# Altium schematic sheet 5_UbloxGps.SchDoc(5_UbloxGps)
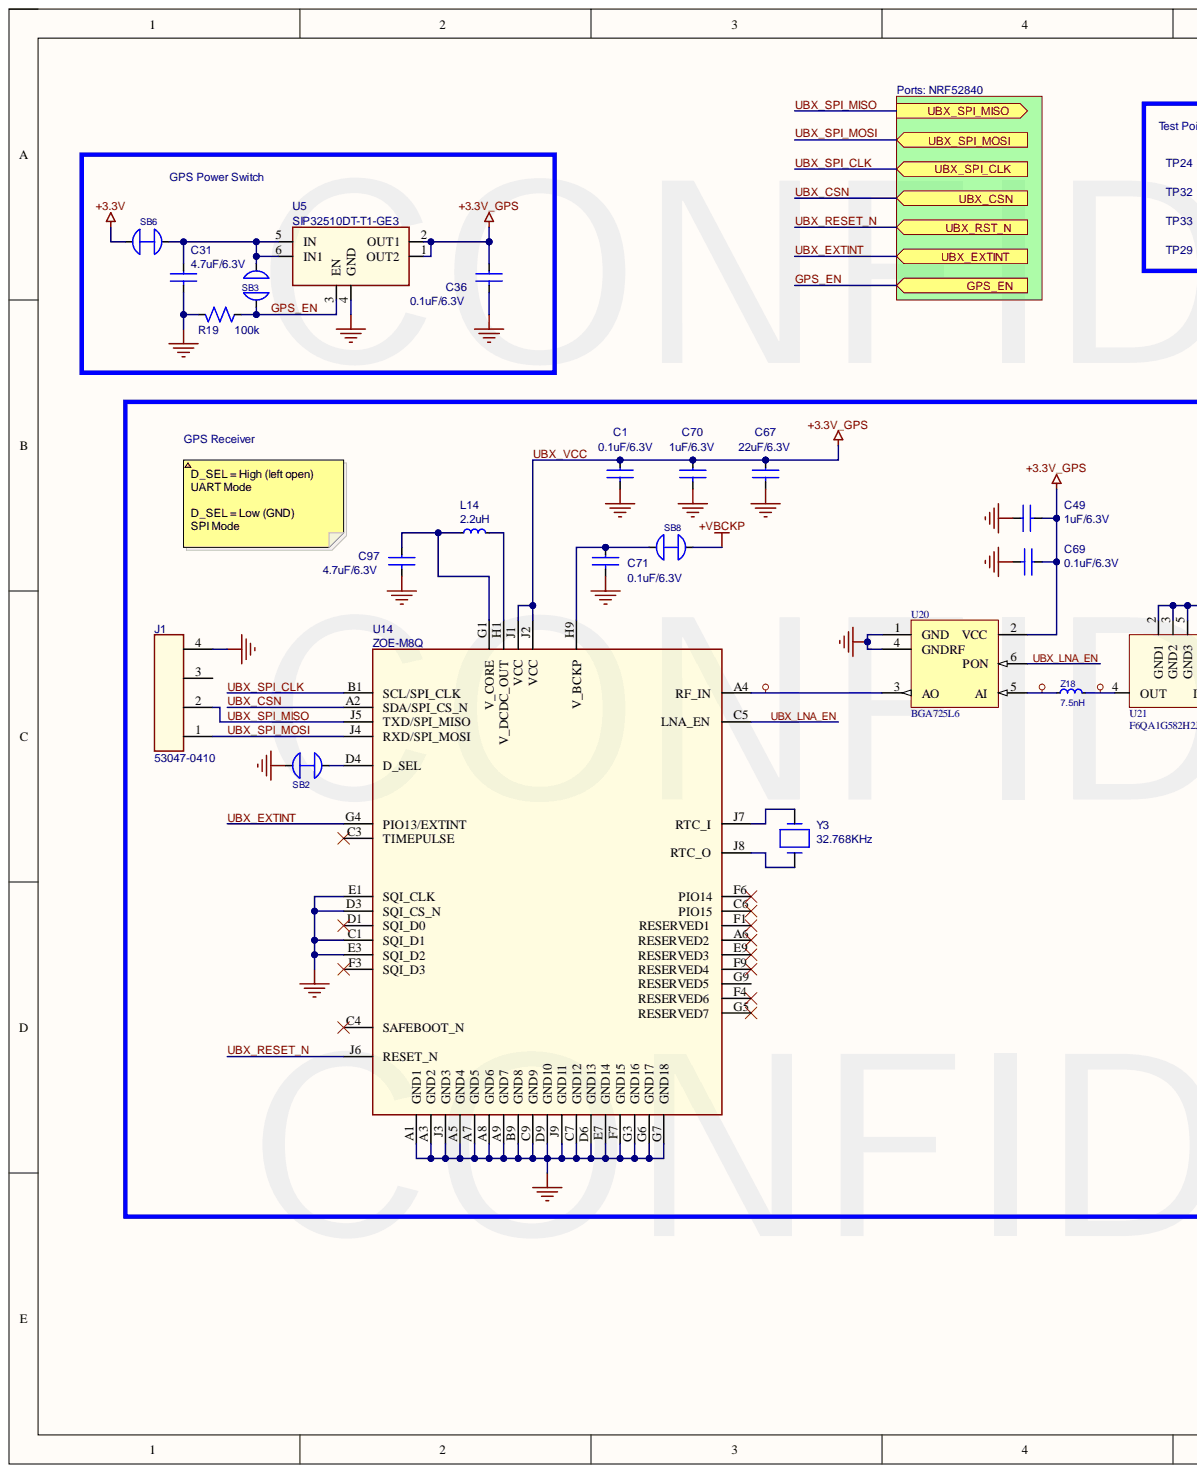
[diagram p0: 5_UbloxGps.SchDoc, left]
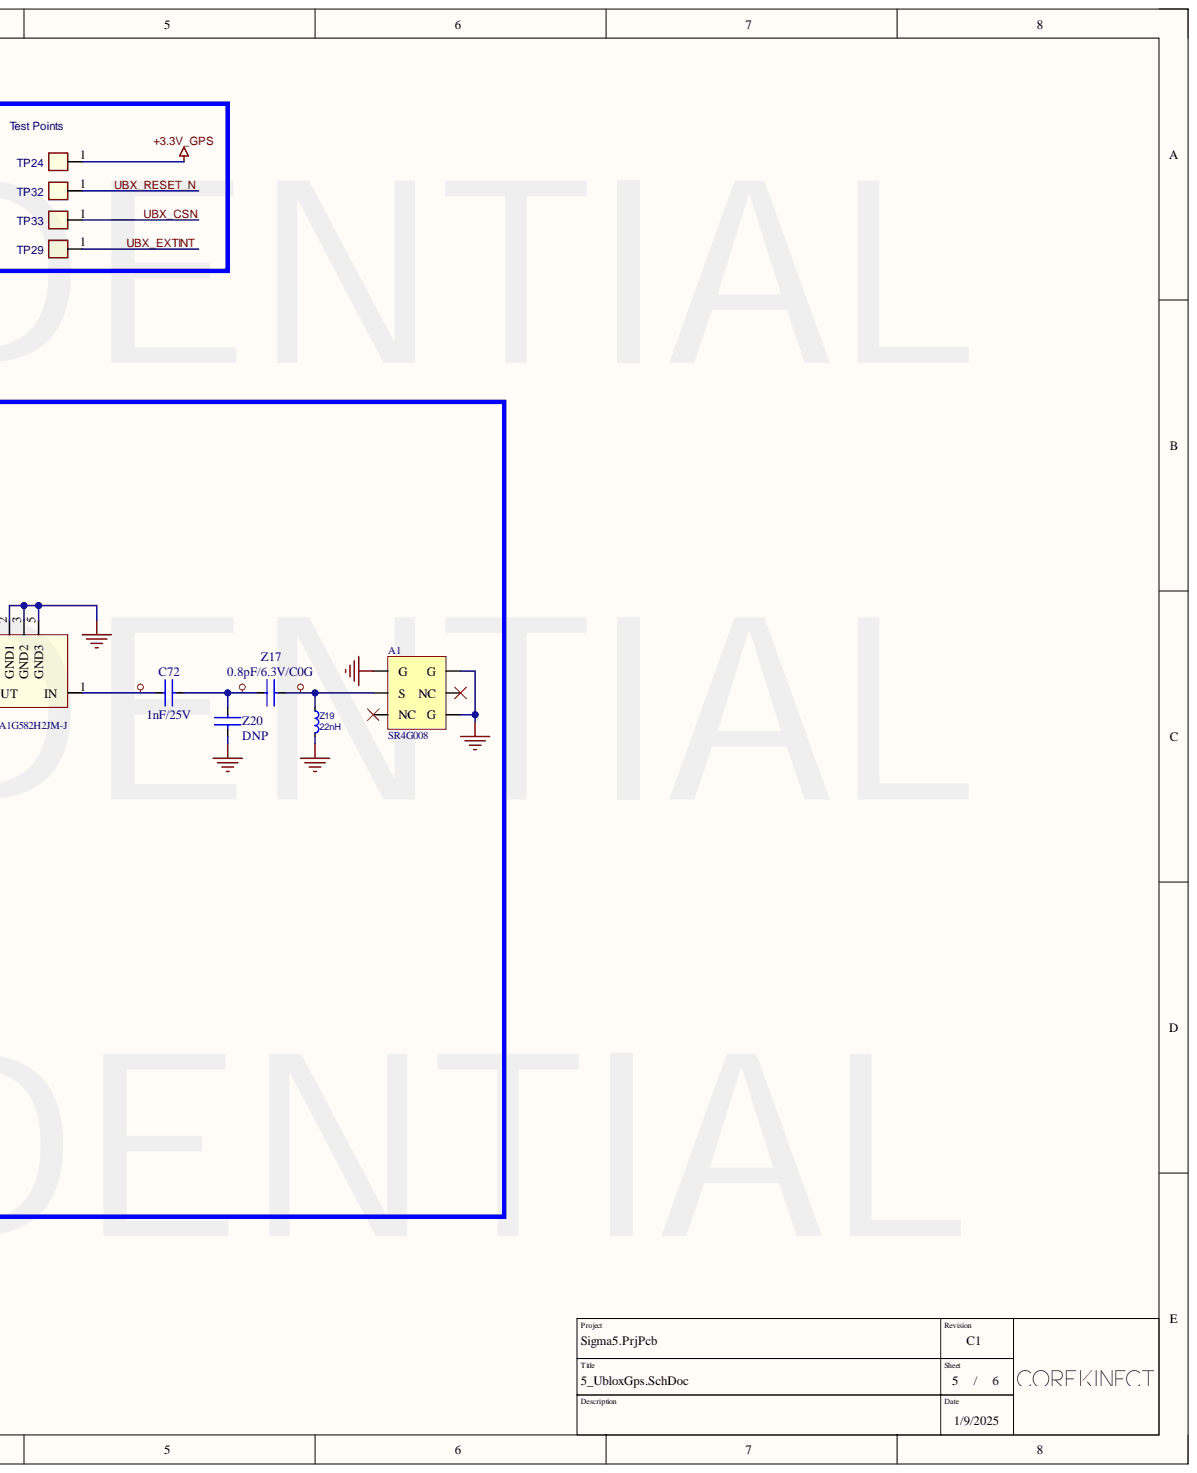
[diagram p1: 5_UbloxGps.SchDoc, right]

## Parts
A1  SR4G008  [SR4G008]
C1  0.1uF/6.3V  [CAP_0402]
C31  4.7uF/6.3V  [CAP_0603]
C36  0.1uF/6.3V  [CAP_0402]
C49  1uF/6.3V  [CAP_0402]
C67  22uF/6.3V  [CAP_0603]
C69  0.1uF/6.3V  [CAP_0402]
C70  1uF/6.3V  [CAP_0402]
C71  0.1uF/6.3V  [CAP_0402]
C72  1nF/25V  [CAP_0402]
C97  4.7uF/6.3V  [CAP_0603]
J1  53047-0410  [0530470410_Molex]
L14  2.2uH  [IND_0806]
R19  100k  [RES_0402]
SB2  SOLDER BRIDGE CLOSED  [SOLDER_BRIDGE_CLOSED_5mil]  (value not drawn)
SB3  SOLDER BRIDGE OPEN  [SOLDER_BRIDGE_OPEN]  (value not drawn)  (no BOM)
SB6  SOLDER BRIDGE CLOSED  [RES_0402]  (value not drawn)
SB8  SOLDER BRIDGE CLOSED  [RES_0402]  (value not drawn)
TP24  TP  [SMT_TP35_SM]
TP29  TP  [SMT_TP35_SM]
TP32  TP  [SMT_TP35_SM]
TP33  TP  [SMT_TP35_SM]
U5  SIP32510DT-T1-GE3  [SOT-23-6]
U14  ZOE-M8Q  [ZOE-M8]
U20  BGA725L6  [INF-TSLP-6-2_V]
U21  F6QA1G582H2JM-J  [F6QA1G582H2JM-J]
Y3  32.768KHz  [XTAL_CM7V-T1A]
Z17  0.8pF/6.3V/C0G  [CAP_0402]
Z18  7.5nH  [CAP_0402]
Z19  22nH  [CAP_0402]
Z20  DNP  [CAP_0402]

## Ports
GPS_EN, UBX_CSN, UBX_EXTINT, UBX_RST_N, UBX_SPI_CLK, UBX_SPI_MISO, UBX_SPI_MOSI

## Nets
+3.3V: SB6.1
+VBCKP: SB8.2
GND: A1.3(G) A1.4(G) A1.6(G) C1.2 C31.2 C36.2 C49.1 C67.2 C69.2 C70.2 C71.2 C97.2 J1.4 R19.2 SB2.1 U5.4(GND) U14.A1(GND1) U14.A3(GND2) U14.A5(GND4) U14.A7(GND5) U14.A8(GND6) U14.A9(GND7) U14.B9(GND8) U14.C1(SQI_D1) U14.C7(GND12) U14.C9(GND9) U14.D3(SQI_CS_N) U14.D6(GND13) U14.D9(GND10) U14.E1(SQI_CLK) U14.E3(SQI_D2) U14.E7(GND14) U14.F7(GND15) U14.G3(GND16) U14.G6(GND17) U14.G7(GND18) U14.J3(GND3) U14.J9(GND11) U20.1(GND) U20.4(GNDRF) U21.2(GND1) U21.3(GND2) U21.5(GND3) Z19.2 Z20.2
GPS_EN: R19.1 SB3.1 U5.3(EN) ; port GPS_EN
UBX_CSN: TP33.1 U14.A2(SDA/SPI_CS_N) ; port UBX_CSN
UBX_EXTINT: TP29.1 U14.G4(PIO13/EXTINT) ; port UBX_EXTINT
UBX_LNA_EN: U14.C5(LNA_EN) U20.6(PON)
UBX_RESET_N: TP32.1 U14.J6(RESET_N) ; port UBX_RST_N
UBX_SPI_CLK: U14.B1(SCL/SPI_CLK) ; port UBX_SPI_CLK
UBX_SPI_MISO: J1.2 U14.J5(TXD/SPI_MISO) ; port UBX_SPI_MISO
UBX_SPI_MOSI: J1.1 U14.J4(RXD/SPI_MOSI) ; port UBX_SPI_MOSI
UBX_VCC: C1.1 C36.1 C49.2 C67.1 C69.1 C70.1 TP24.1 U5.1(OUT2) U5.2(OUT1) U14.J1(VCC) U14.J2(VCC) U20.2(VCC)
NetA1_2: A1.2(S) Z17.2 Z19.1
NetC31_1: C31.1 SB3.2 SB6.2 U5.5(IN) U5.6(IN1)
NetC71_1: C71.1 SB8.1 U14.H9(V_BCKP)
NetC72_1: C72.1 U21.1(IN)
NetC72_2: C72.2 Z17.1 Z20.1
NetC97_1: C97.1 L14.1 U14.G1(V_CORE)
NetL14_2: L14.2 U14.H1(V_DCDC_OUT)
NetSB2_2: SB2.2 U14.D4(D_SEL)
NetU14_A4: U14.A4(RF_IN) U20.3(AO)
NetU14_J7: U14.J7(RTC_I) Y3.2
NetU14_J8: U14.J8(RTC_O) Y3.1
NetU20_5: U20.5(AI) Z18.1
NetU21_4: U21.4(OUT) Z18.2

Not connected: A1 1(NC) 5(NC); J1 3; U14 A6(RESERVED2) C3(TIMEPULSE) C4(SAFEBOOT_N) C6(PIO15) D1(SQI_D0) E9(RESERVED3) F1(RESERVED1) F3(SQI_D3) F4(RESERVED6) F6(PIO14) F9(RESERVED4) G5(RESERVED7) G9(RESERVED5)

## Library data of the parts
A1 = SR4G008: RF ANT 1.561GHZ/1.575GHZ CHIP; Manufacturer 1: Antenova; Notes: GNSS antenna; Number of Bands: 1; Return Loss: -12dB; Category: RF Antennas; Component Kind: Standard; ComponentLink1Description: http://www.antenova-m2m.com/wp-content/uploads/2015/11/Sinica-GNSS-SR4G008-PS-1.1.pdf?src-supplier=Digi-Key; ComponentLink1URL: http://www.antenova-m2m.com/wp-content/uploads/2015/11/Sinica-GNSS-SR4G008-PS-1.1.pdf?src-supplier=Digi-Key; Height: 0.015inch; Manufacturer Part Number 1: SR4G008; Mount: Surface Mount; Packaging: Tape and Reel; Pricing 1: 1=2.79000, 10=2.50400, 25=2.36240, 100=2.01290, 250=1.89000, 500=1.65376 (USD); RoHS: Yes; Stock 1: 11941; Supplier 1: Digi-Key; Supplier Part Number 1: 627-1063-1-ND; Termination: Solder; Library Name: CoreKinectIntegratedLibrary.IntLib
C1 C36 C49 C69-C71 = CAP_0402: Component Kind: Standard; Package / Case: 0402; Library Name: CoreKinectIntegratedLibrary.IntLib
C31 C67 C97 = CAP_0603: Component Kind: Standard; Package / Case: 0603; Library Name: CoreKinectIntegratedLibrary.IntLib
C72 = CAP_0402: Notes: GNSS DC block - High Q; Component Kind: Standard; Package / Case: 0402; Library Name: CoreKinectIntegratedLibrary.IntLib
J1 = MOLEX_53047-0410: GLOVE, POLYAMIDE, L/FREE, PU, SIZE 6 - More Details; Manufacturer 1: Molex; Contact Resistance: 20mR; Current: 1A; Gender: Male; Glow Wire Compliant: Non-compliant; Insulation Resistance: 100MR; Max Voltage Rating (AC): 125V; Number of Circuits: 4; Pitch: 1.25mm; Plating: Tin; Case/Package: 0410; Category: Headers and Wire Housings; Color: Natural; Component Kind: Standard; ComponentLink1Description: https://datasheet.ciiva.com/7844/1838335-7844032.pdf?src-supplier=Element14; ComponentLink1URL: https://datasheet.ciiva.com/7844/1838335-7844032.pdf?src-supplier=Element14; ComponentLink2Description: https://datasheet.ciiva.com/30144/347020530470410-pcb-headers-30144214.pdf?src-supplier=Onlinecomponents.com; ComponentLink2URL: https://datasheet.ciiva.com/30144/347020530470410-pcb-headers-30144214.pdf?src-supplier=Onlinecomponents.com; ComponentLink3Description: https://datasheet.ciiva.com/20207/22-3930e-20207527.pdf?src-supplier=Rapid+Electronics; ComponentLink3URL: https://datasheet.ciiva.com/20207/22-3930e-20207527.pdf?src-supplier=Rapid+Electronics; ComponentLink4Description: https://datasheet.ciiva.com/5149/0530470410-pcb-headers-170953-5149004.pdf?src-supplier=Mouser; ComponentLink4URL: https://datasheet.ciiva.com/5149/0530470410-pcb-headers-170953-5149004.pdf?src-supplier=Mouser; ComponentLink5Description: https://datasheet.ciiva.com/11746/0900766b8024e2fd-11746351.pdf?src-supplier=RSComponents; ComponentLink5URL: https://datasheet.ciiva.com/11746/0900766b8024e2fd-11746351.pdf?src-supplier=RSComponents; ComponentLink6Description: https://datasheet.ciiva.com/16658/0530470410-pcb-headers-16658419.pdf?src-supplier=Avnet+Europe; ComponentLink6URL: https://datasheet.ciiva.com/16658/0530470410-pcb-headers-16658419.pdf?src-supplier=Avnet+Europe; ComponentLink7Description: https://datasheet.ciiva.com/27054/1932483-27054996.pdf?src-supplier=Jameco; ComponentLink7URL: https://datasheet.ciiva.com/27054/1932483-27054996.pdf?src-supplier=Jameco; ComponentLink8Description: https://datasheet.ciiva.com/14156/ge116819-116832-e-14156235.pdf?src-supplier=Distrelec; ComponentLink8URL: https://datasheet.ciiva.com/14156/ge116819-116832-e-14156235.pdf?src-supplier=Distrelec; ComponentLink9Description: https://datasheet.ciiva.com/10088/0530470410-pcb-headers-10088984.pdf?src-supplier=Avnet; ComponentLink9URL: https://datasheet.ciiva.com/10088/0530470410-pcb-headers-10088984.pdf?src-supplier=Avnet; Contact Material: Bronze,Tin; Contact Plating: Tin; Current Rating: 1A; Flammability Rating: UL94 V-0; Housing Color: Natural; Housing Material: Polyamide; Lead Free: Lead Free; Manufacturer Part Number 1: 53047-0410; Max Operating Temperature: 85°C; Min Operating Temperature: -40°C; Mount: Through Hole; Number of Contacts: 4; Number of Positions: 4; Number of Rows: 1; Orientation: Vertical; Package Quantity: 5000; Packaging: Tray; Pricing 1: 1=0.28000, 10=0.26100, 100=0.18300, 500=0.15688, 1000=0.13073, 5000=0.11205, 10000=0.10458 (USD); Radiation Hardening: No; REACH SVHC: Unknown; RoHS: Yes; Stock 1: 47330; Supplier 1: Digi-Key; Supplier Part Number 1: WM1733-ND; Termination: Solder; Voltage: 125V; Voltage Rating: 125V; Voltage Rating (AC): 125V; Voltage Rating (DC): 125V; Working Voltage: 125V; Library Name: CoreKinectIntegratedLibrary.IntLib
L14 = LQM2MPN2R2NG0L: Ind Power Chip Shielded Multi-Layer 2.2uH 30% 1MHz Ferrite 1.2A 0806 Embossed T/R; Manufacturer 1: Murata; DC Resistance (DCR): 138mR; Self Resonant Frequency: 40MHz; Case Code (Imperial): 0806; Case Code (Metric): 2016; Case/Package: 0806; Category: Fixed Inductors; Component Kind: Standard; ComponentLink1Description: https://datasheet.ciiva.com/30490/0900766b812561f8-30490180.pdf?src-supplier=RSComponents; ComponentLink1URL: https://datasheet.ciiva.com/30490/0900766b812561f8-30490180.pdf?src-supplier=RSComponents; ComponentLink2Description: https://datasheet.ciiva.com/4960/product-4960213.pdf?src-supplier=Mouser; ComponentLink2URL: https://datasheet.ciiva.com/4960/product-4960213.pdf?src-supplier=Mouser; ComponentLink3Description: https://datasheet.ciiva.com/29696/l0075s0104lqm2mp-g0-29696295.pdf?src-supplier=Digi-Key; ComponentLink3URL: https://datasheet.ciiva.com/29696/l0075s0104lqm2mp-g0-29696295.pdf?src-supplier=Digi-Key; ComponentLink4Description: https://datasheet.ciiva.com/29696/l0075s0104lqm2mp-g0-29696296.pdf?src-supplier=Digi-Key; ComponentLink4URL: https://datasheet.ciiva.com/29696/l0075s0104lqm2mp-g0-29696296.pdf?src-supplier=Digi-Key; ComponentLink5Description: https://datasheet.ciiva.com/8508/l0075s0104lqm2mp-g0-8508441.pdf?src-supplier=Avnet; ComponentLink5URL: https://datasheet.ciiva.com/8508/l0075s0104lqm2mp-g0-8508441.pdf?src-supplier=Avnet; ComponentLink6Description: https://datasheet.ciiva.com/29696/l0075s0104lqm2mp-g0-29696297.pdf?src-supplier=Digi-Key; ComponentLink6URL: https://datasheet.ciiva.com/29696/l0075s0104lqm2mp-g0-29696297.pdf?src-supplier=Digi-Key; Core Material: Ferrite; Current Rating: 1.2A; DC Current: 1.2A; Depth: 1.75mm; Height: 1mm; Height - Seated (Max): 0.039inch; Inductance: 2.2uH; Inductance Tolerance: 30%; Lead Free: Lead Free; Length: 0.079inch; Manufacturer Part Number 1: LQM2MPN2R2NG0L; Max DC Current: 1.2A; Max Operating Temperature: 125°C; Military Standard: Not; Min Operating Temperature: -55°C; Mount: Surface Mount; Package Quantity: 1; Packaging: Tape and Reel; Pricing 1: 1=0.34000, 10=0.30600, 25=0.26760, 50=0.22920, 100=0.21010, 250=0.19100, 500=0.17954, 1000=0.13752 (USD); Radiation Hardening: No; REACH SVHC: No SVHC; RoHS: Yes; Shielding: Shielded; Stock 1: 16378; Supplier 1: Digi-Key; Supplier Part Number 1: 490-7786-1-ND; Termination: SMD/SMT; Test Frequency: 1MHz; Tolerance: 30%; Width: 0.063inch; Library Name: CoreKinectIntegratedLibrary.IntLib
R19 = RES_0402: Component Kind: Standard; Package / Case: 0402; Library Name: CoreKinectIntegratedLibrary.IntLib
SB2 = MISC-000003: Solder bridge, closed, 5mil; Manufacturer 1: CoreKinect; Manufacturer Part Number 1: SB-Closed-5mil; Database Table Name: Misc; Component Kind: Net Tie; CPN: MISC-000003; Library Name: CoreKinectDatabaseLibrary.DbLib
SB3 = SOLDER_BRIDGE_OPEN: Library Name: CoreKinectIntegratedLibrary.IntLib
SB6 SB8 = MISC-00000B: Short circuit 0402; Manufacturer 1: CoreKinect; Manufacturer Part Number 1: Short-Circuit; Database Table Name: Misc; Component Kind: Net Tie; CPN: MISC-00000B; Library Name: CoreKinectDatabaseLibrary.DbLib
TP24 TP29 TP32 TP33 = CON1_1: PN: SMT_TP35; Component Kind: Standard
U5 = SIP32510DT-T1-GE3: VISHAY - SIP32510DT-T1-GE3 - 3A, 1.1V to 5.5 V, Slew Rate Controlled Load Switch in TSOT23 package; Fault Protection: Reverse Current; Interface: On/Off; Max Power Dissipation: 833mW; Max Supply Voltage: 5.5V; Min Supply Voltage: 1.2V; Nominal Supply Current: 1uA; Number of Outputs: 1; On-State Resistance: 53mR; Output Configuration: High Side; Turn-Off Delay Time: 1us; Turn-On Delay Time: 400us; Case/Package: SOT-23-6; Category: Hot Swap Controllers; Component Kind: Standard; ComponentLink1Description: https://datasheet.ciiva.com/23940/sip32510-23940565.pdf?src-supplier=Newark; ComponentLink1URL: https://datasheet.ciiva.com/23940/sip32510-23940565.pdf?src-supplier=Newark; ComponentLink2Description: https://datasheet.ciiva.com/22747/1904514-22747782.pdf?src-supplier=Element14; ComponentLink2URL: https://datasheet.ciiva.com/22747/1904514-22747782.pdf?src-supplier=Element14; ComponentLink3Description: https://datasheet.ciiva.com/29899/sip32510-29899142.pdf?src-supplier=Avnet; ComponentLink3URL: https://datasheet.ciiva.com/29899/sip32510-29899142.pdf?src-supplier=Avnet; ComponentLink4Description: https://datasheet.ciiva.com/29593/sip32510-29593479.pdf?src-supplier=Digi-Key; ComponentLink4URL: https://datasheet.ciiva.com/29593/sip32510-29593479.pdf?src-supplier=Digi-Key; Manufacturer 1: Vishay; Manufacturer Part Number 1: SIP32510DT-T1-GE3; Max Operating Temperature: 125°C; Max Output Current: 3A; Min Operating Temperature: -40°C; Mount: Surface Mount; Number of Pins: 23; Package Quantity: 3000; Packaging: Tape and Reel; Pricing 1: 1=0.39000, 10=0.33800, 25=0.31520, 100=0.23400, 250=0.19800, 500=0.18900, 1000=0.13950 (USD); Radiation Hardening: No; REACH SVHC: Unknown; RoHS: Yes; Stock 1: 10915; Supplier 1: Digi-Key; Supplier Part Number 1: SIP32510DT-T1-GE3CT-ND; Library Name: CoreKinectIntegratedLibrary.IntLib
U14 = ZOE-M8Q-0: Ultra small, super low power 1.8V u-blox M8 GNSS SiP module; Component Kind: Standard; ComponentLink1Description: http://datasheet.octopart.com/ZOE-M8Q-0-u-blox-datasheet-145429062.pdf?src-supplier=TME; ComponentLink1URL: http://datasheet.octopart.com/ZOE-M8Q-0-u-blox-datasheet-145429062.pdf?src-supplier=TME; Manufacturer 1: u-blox; Manufacturer Part Number 1: ZOE-M8Q-0; Pricing 1: 1=29.00000, 100=14.70010 (USD); Stock 1: 2851; Supplier 1: Digi-Key; Supplier Part Number 1: 672-ZOE-M8Q-0CT-ND; Library Name: CoreKinectIntegratedLibrary.IntLib
U20 = BGA725L6: Manufacturer 1: Infineon; Component Kind: Standard; Manufacturer Part Number 1: BGA725L6; Number of Pins: 6; Pricing 1: 1=0.38000, 10=0.33500, 25=0.30240, 100=0.26460, 250=0.23220, 500=0.20520, 1000=0.16200, 5000=0.14364 (USD); RoHS: No; Stock 1: 49401; Supplier 1: Digi-Key; Supplier Part Number 1: BGA725L6E6327FTSA1CT-ND; Library Name: CoreKinectIntegratedLibrary.IntLib
U21 = F6QA1G582H2JM-J: FILTER SAW 1.582GHZ 5SMD; Manufacturer 1: Taiyo Yuden; Frequency: 1.585GHz; Impedance: 50R; Insertion Loss: 1.8dB; Category: EMI / RFI Components; Component Kind: Standard; ComponentLink1Description: http://www.mouser.com/ds/2/396/sawfilter01_e-5062.pdf?src-supplier=Mouser; ComponentLink1URL: http://www.mouser.com/ds/2/396/sawfilter01_e-5062.pdf?src-supplier=Mouser; ComponentLink2Description: https://www.yuden.co.jp/productdata/catalog/sawfilter01_e.pdf?src-supplier=Digi-Key; ComponentLink2URL: https://www.yuden.co.jp/productdata/catalog/sawfilter01_e.pdf?src-supplier=Digi-Key; Load Impedance: 50R; Manufacturer Part Number 1: F6QA1G582H2JM-J; Max Operating Temperature: 85°C; Min Operating Temperature: -30°C; Mount: Surface Mount; Number of Pins: 5; Package Quantity: 5000; Packaging: Tape and Reel; Pricing 1: 1=0.35000, 10=0.32200, 25=0.30680, 50=0.29700, 100=0.28710, 250=0.27224, 500=0.22770, 1000=0.17672 (USD); Radiation Hardening: No; RoHS: Yes; Stock 1: 114842; Supplier 1: Digi-Key; Supplier Part Number 1: 587-3990-1-ND; Termination: SMD/SMT; Library Name: CoreKinectIntegratedLibrary.IntLib
Y3 = CM7V-T1A: Crystal 32.768KHZ 7.0PF SMD; Manufacturer 1: Micro Crystal; Manufacturer Part Number 1: CM7V-T1A-32.768KHZ-7PF-20PPM-TA-QC; Component Kind: Standard; Pricing 1: 1000=0.19840, 3000=0.18600, 5000=0.17980, 10000=0.17360 (USD); RoHS: No; Stock 1: 4000; Supplier 1: Digi-Key; Supplier Part Number 1: 2195-CM7V-T1A-32.768KHZ-6PF-20PPM-TA-QCTR-ND; Library Name: CoreKinectIntegratedLibrary.IntLib
Z17 Z20 = CAP_0402: Notes: GNSS tuning - High Q; Component Kind: Standard; Package / Case: 0402; Library Name: CoreKinectIntegratedLibrary.IntLib
Z18 Z19 = IND_0402: Notes: GNSS tuning - High Q; Component Kind: Standard; Package / Case: 0402; Library Name: CoreKinectIntegratedLibrary.IntLib
